# Altium schematic sheet Page2_.SchDoc
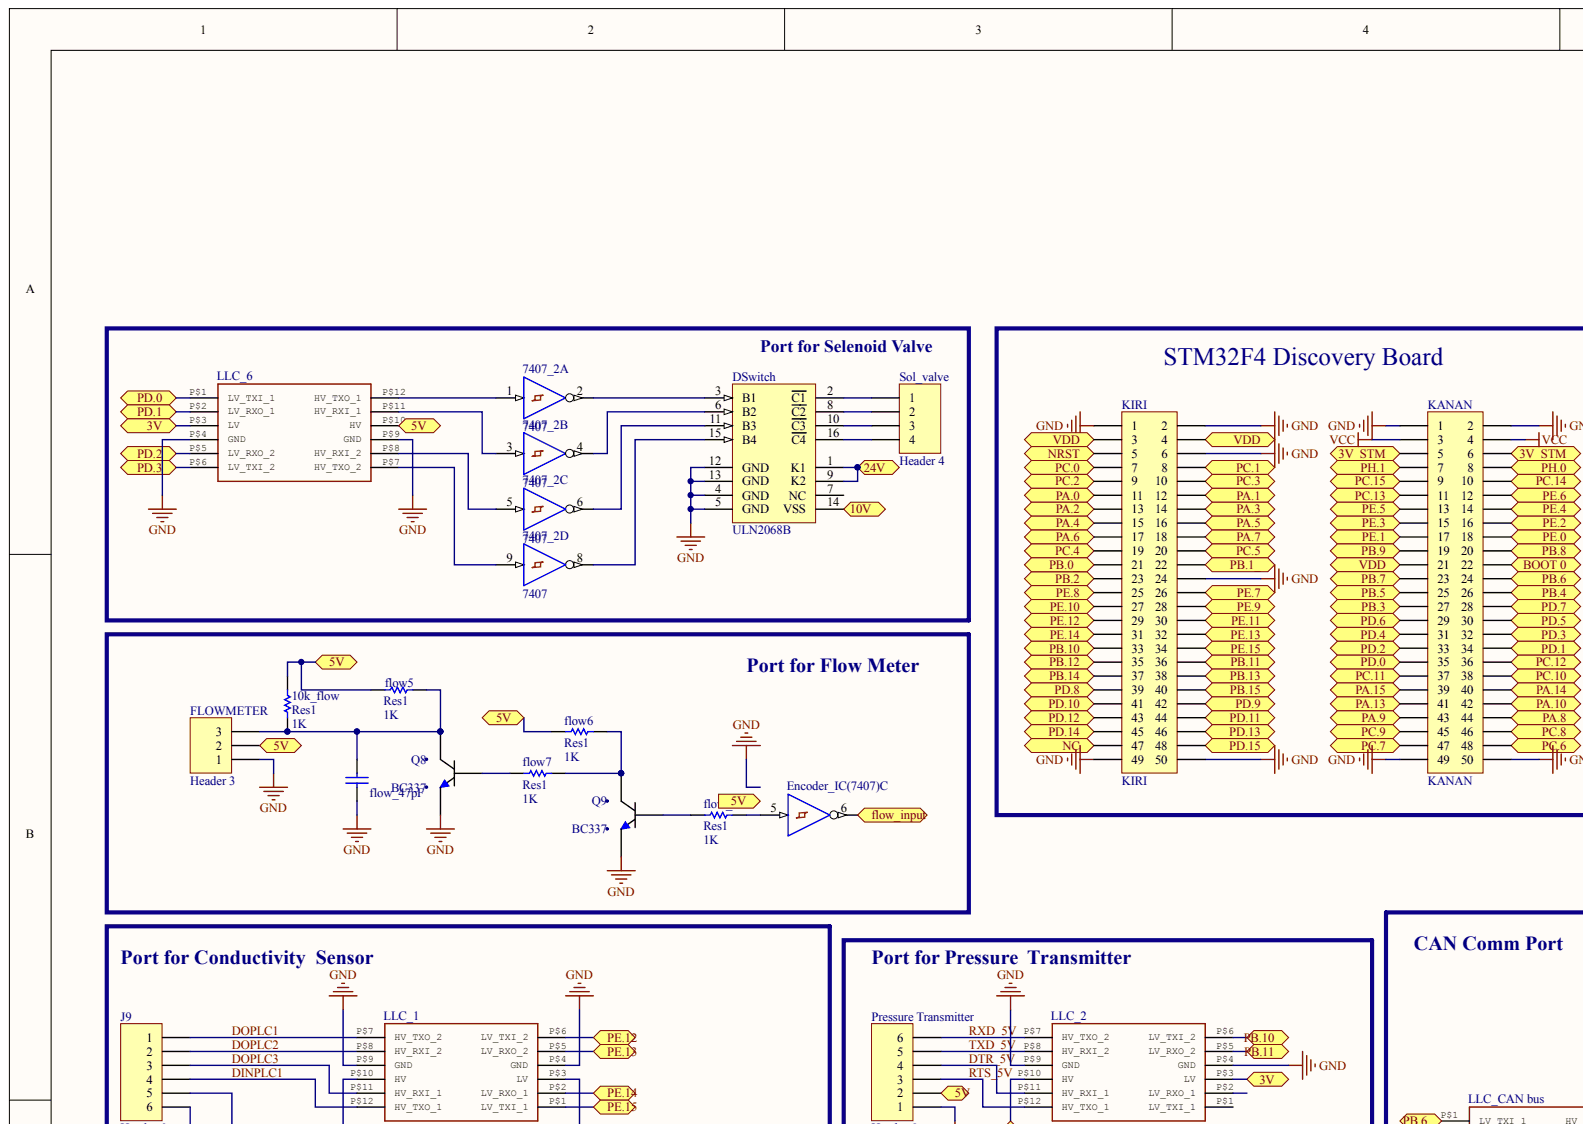
[diagram p0: Page2_.SchDoc, top left]
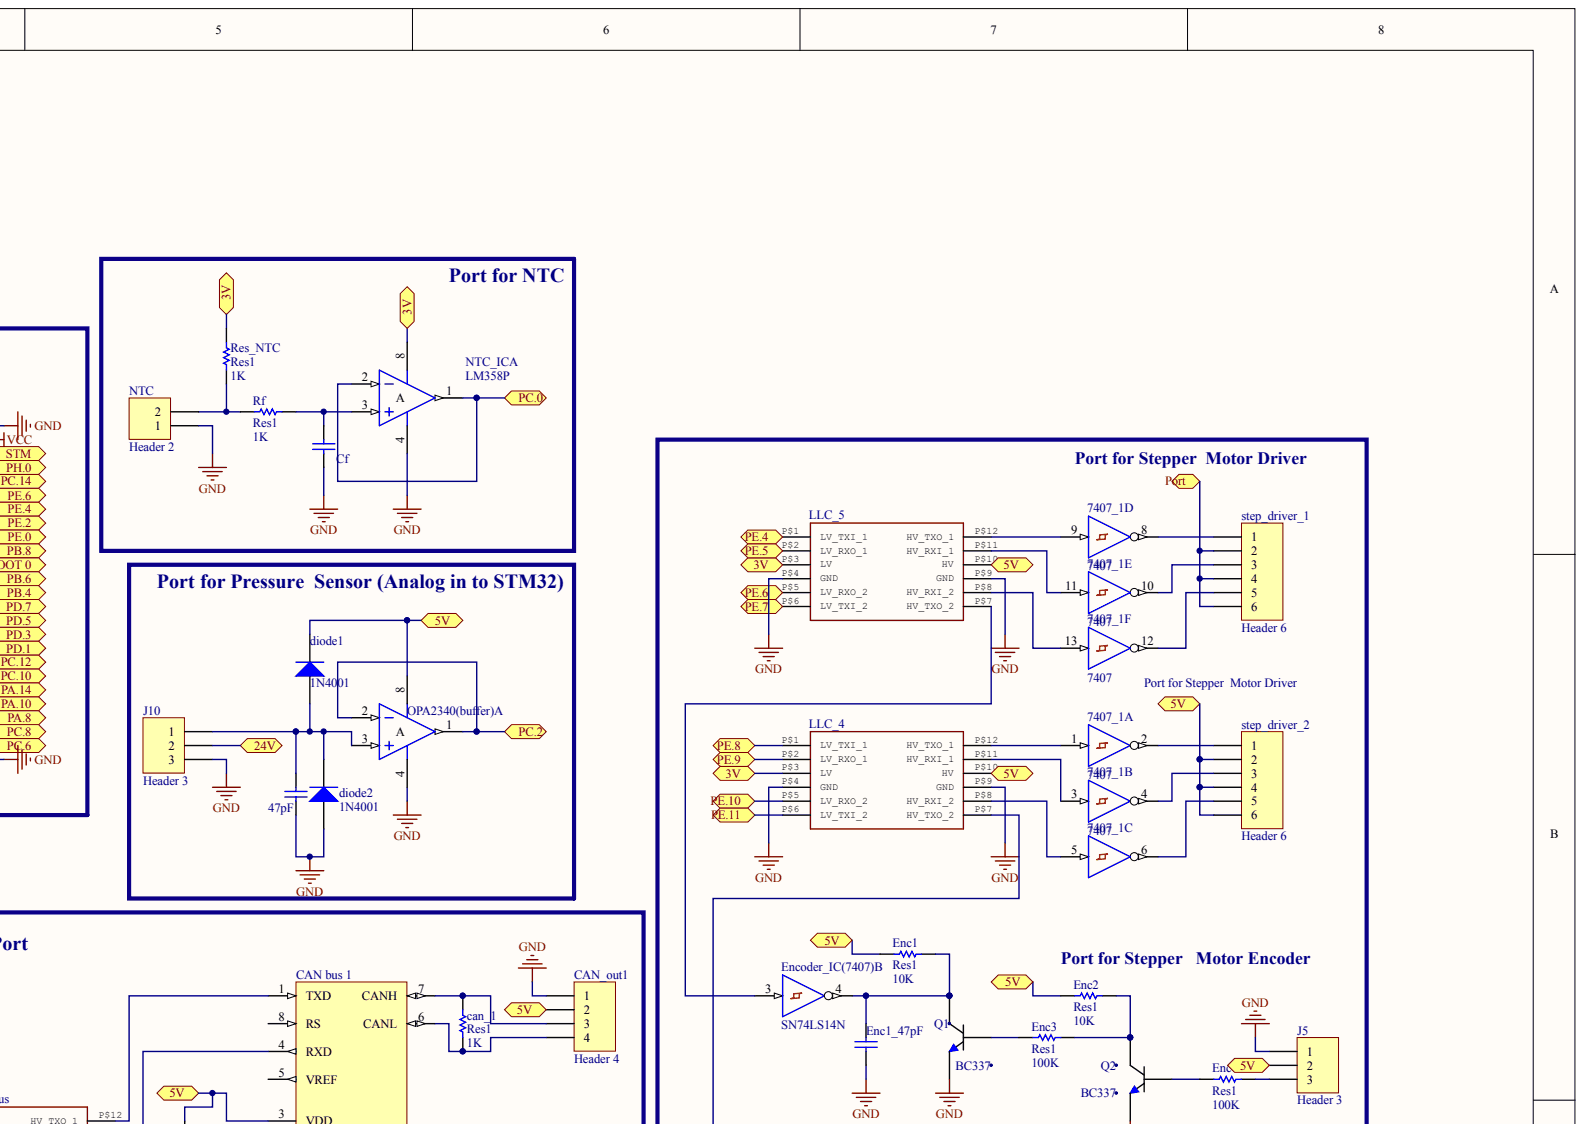
[diagram p1: Page2_.SchDoc, top right]
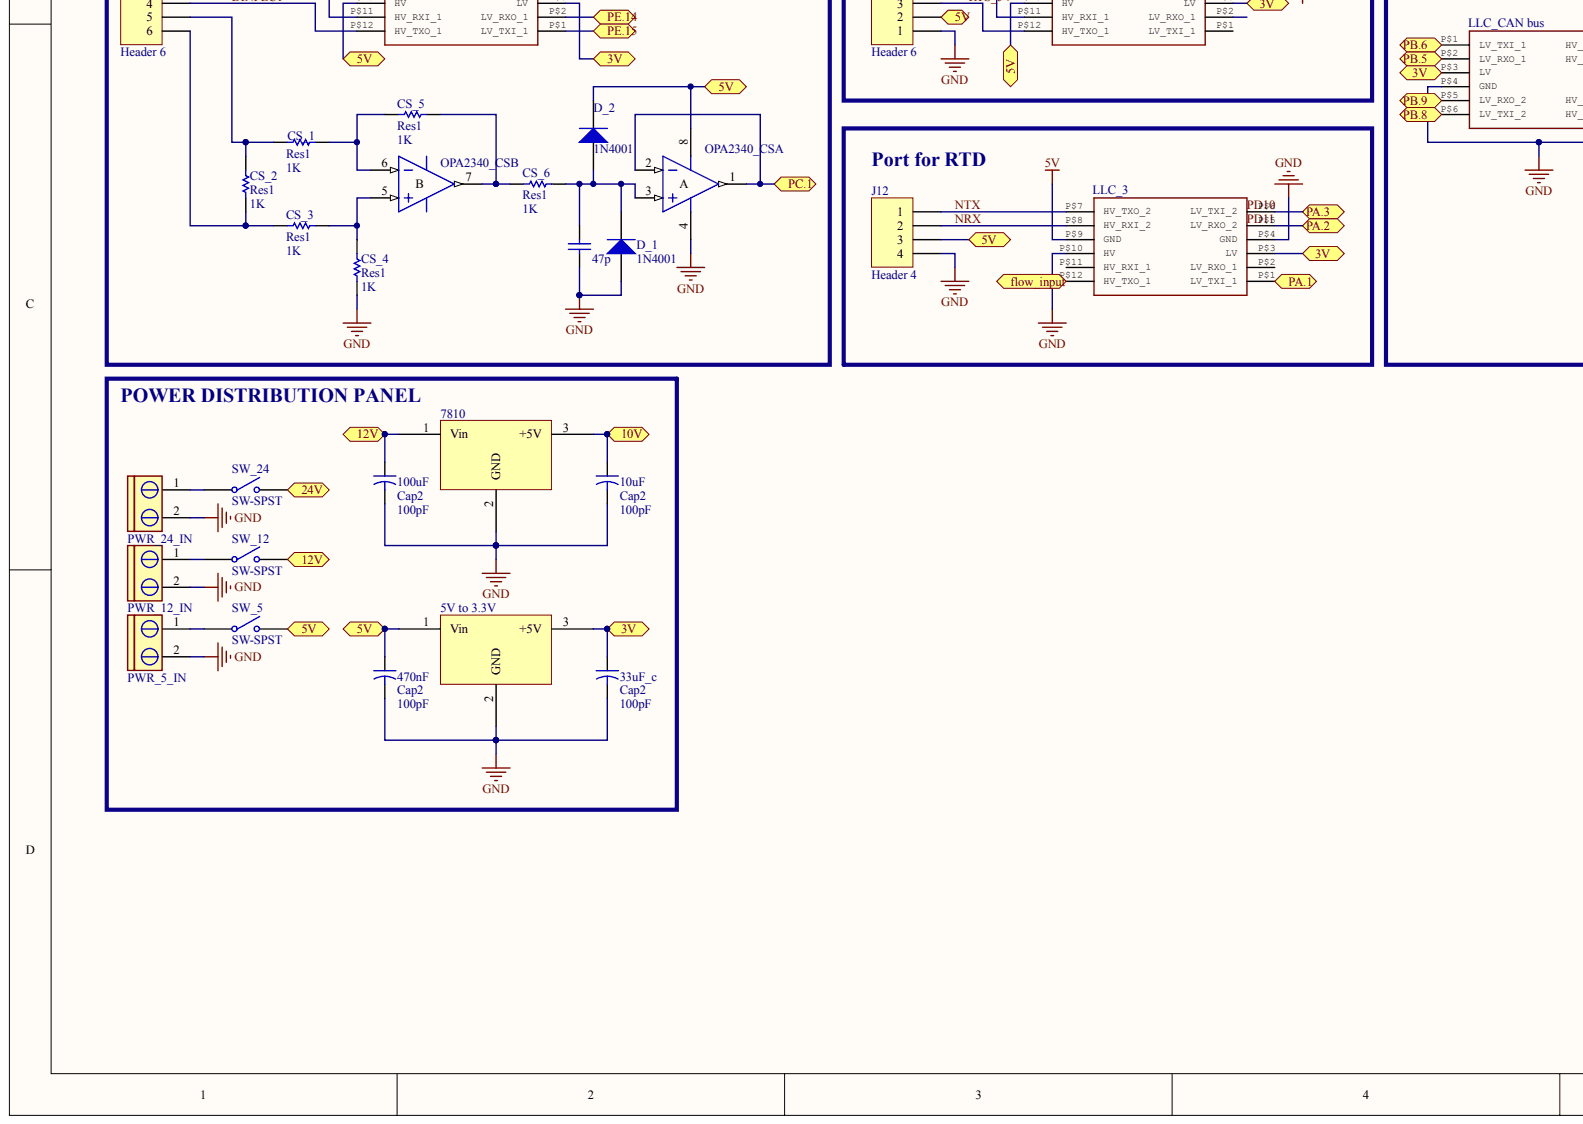
[diagram p2: Page2_.SchDoc, bottom left]
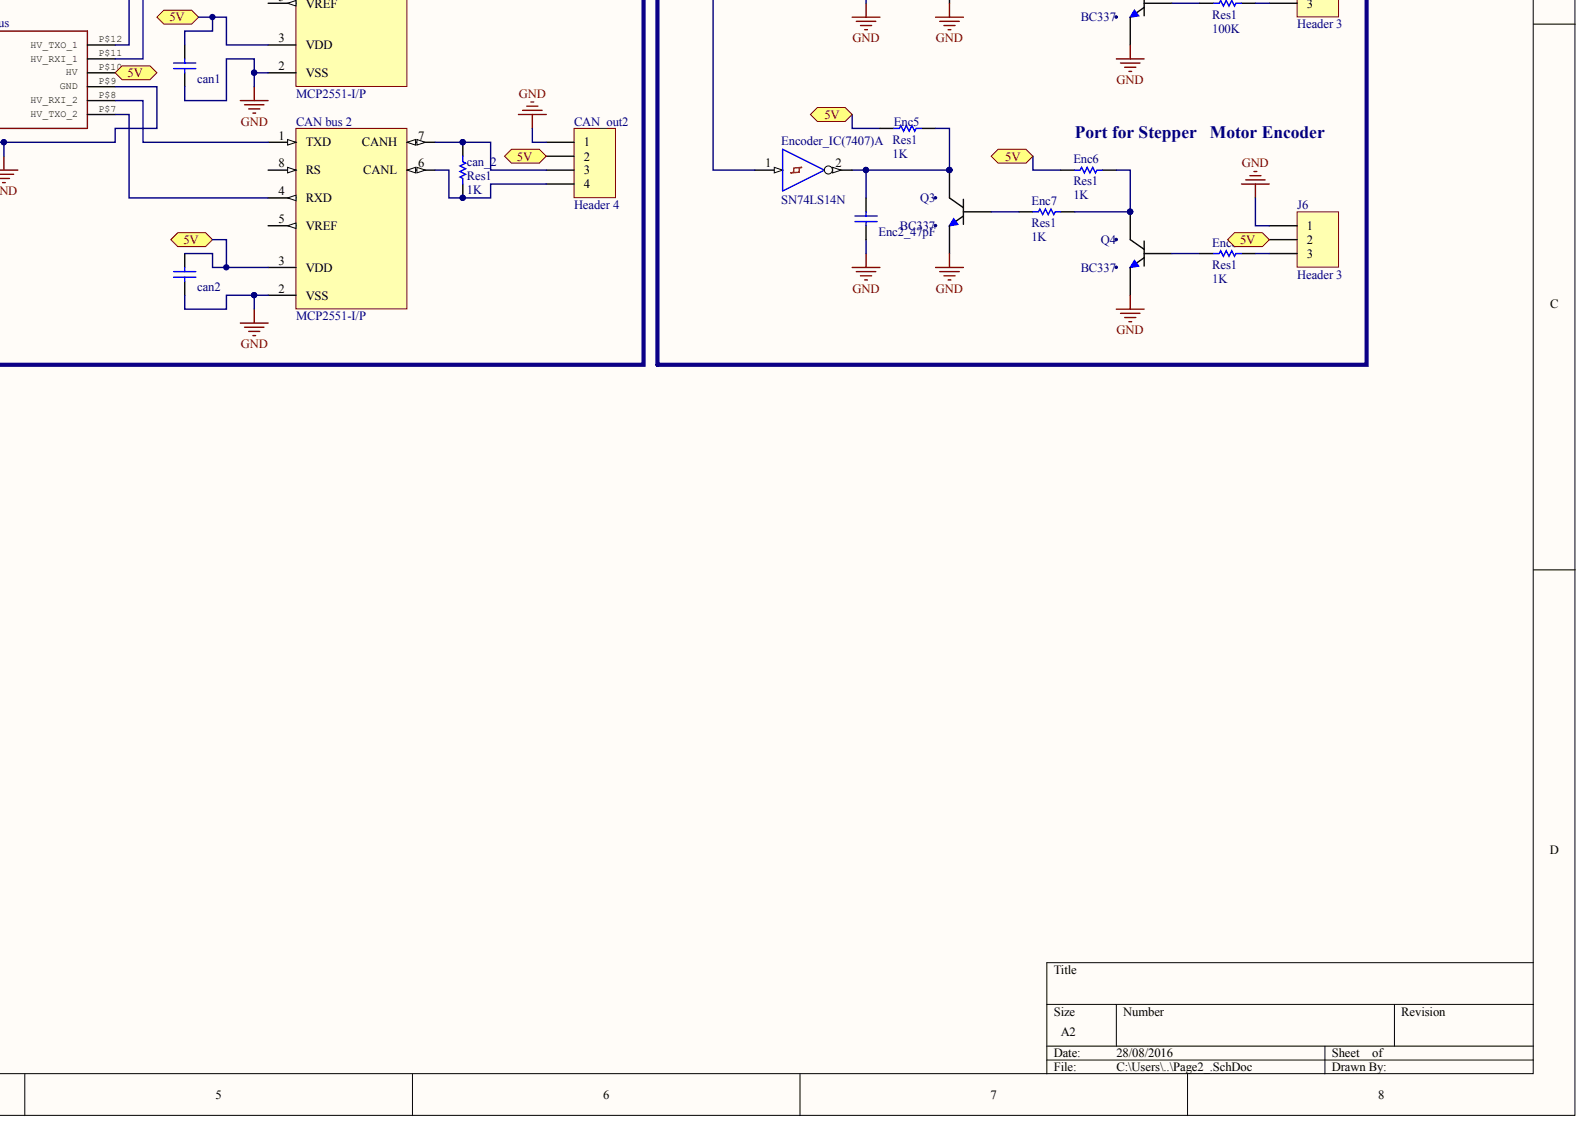
[diagram p3: Page2_.SchDoc, bottom right]

## Parts
5V_to_3.3V  7805  (value not drawn)
10k_flow  Res1  value 1K
10uF  Cap2  value 100pF
33uF_c  Cap2  value 100pF
47p  Cap  (value not drawn)
47pF  Cap  (value not drawn)
100uF  Cap2  value 100pF
470nF  Cap2  value 100pF
7407_1  7407  (parts A,B,C,D,E,F)
7407_2  7407  (parts A,B,C,D)
7810  7805  (value not drawn)
can1  Cap  (value not drawn)
can2  Cap  (value not drawn)
CAN_bus_1  MCP2551-I/P
CAN_bus_2  MCP2551-I/P
can_1  Res1  value 1K
can_2  Res1  value 1K
CAN_out1  Header 4
CAN_out2  Header 4
Cf  Cap  (value not drawn)
CS_1  Res1  value 1K
CS_2  Res1  value 1K
CS_3  Res1  value 1K
CS_4  Res1  value 1K
CS_5  Res1  value 1K
CS_6  Res1  value 1K
D_1  1N4001
D_2  1N4001
diode1  1N4001
diode2  1N4001
DSwitch  ULN2068B
Enc1  Res1  value 10K
Enc1_47pF  Cap  (value not drawn)
Enc2  Res1  value 10K
Enc2_47pF  Cap  (value not drawn)
Enc3  Res1  value 100K
Enc4  Res1  value 100K
Enc5  Res1  value 1K
Enc6  Res1  value 1K
Enc7  Res1  value 1K
Enc8  Res1  value 1K
Encoder_IC(7407)  SN74LS14N  (parts A,B,C)
flow5  Res1  value 1K
flow6  Res1  value 1K
flow7  Res1  value 1K
flow_1  Res1  value 1K
flow_47pF  Cap  (value not drawn)
FLOWMETER  Header 3
J5  Header 3
J6  Header 3
J9  Header 6
J10  Header 3
J12  Header 4
KANAN  KANAN
KIRI  KIRI
LLC_1  Logic Level Converter (3.3v - 5v) / https://www.sparkfun.com/products/8745  (value not drawn)
LLC_2  Logic Level Converter (3.3v - 5v) / https://www.sparkfun.com/products/8745  (value not drawn)
LLC_3  Logic Level Converter (3.3v - 5v) / https://www.sparkfun.com/products/8745  (value not drawn)
LLC_4  Logic Level Converter (3.3v - 5v) / https://www.sparkfun.com/products/8745  (value not drawn)
LLC_5  Logic Level Converter (3.3v - 5v) / https://www.sparkfun.com/products/8745  (value not drawn)
LLC_6  Logic Level Converter (3.3v - 5v) / https://www.sparkfun.com/products/8745  (value not drawn)
LLC_CAN_bus  Logic Level Converter (3.3v - 5v) / https://www.sparkfun.com/products/8745  (value not drawn)
NTC  Header 2
NTC_IC  LM358P  (part A)
OPA2340(buffer)  LM358P  (value not drawn)  (part A)
OPA2340_CS  LM358P  (value not drawn)  (parts A,B)
Pressure_Transmitter  Header 6
PWR_5_IN  CON2 pin blue  (value not drawn)
PWR_12_IN  CON2 pin blue  (value not drawn)
PWR_24_IN  CON2 pin blue  (value not drawn)
Q1  BC337
Q2  BC337
Q3  BC337
Q4  BC337
Q8  BC337
Q9  BC337
Res_NTC  Res1  value 1K
Rf  Res1  value 1K
Sol_valve  Header 4
step_driver_1  Header 6
step_driver_2  Header 6
SW_5  SW-SPST
SW_12  SW-SPST
SW_24  SW-SPST

## Ports
3V, 3V_STM, 5V, 10V, 12V, 24V, BOOT 0, FLOW_INPUT, NC, NRST, PA.0, PA.1, PA.2, PA.3, PA.4, PA.5, PA.6, PA.7, PA.8, PA.9, PA.10, PA.13, PA.14, PA.15, PB.0_, PB.1_, PB.2, PB.3, PB.4, PB.5, PB.6, PB.7, PB.8, PB.9, PB.10, PB.11, PB.12, PB.13, PB.14, PB.15, PC.0, PC.1, PC.2, PC.3, PC.4, PC.5, PC.6, PC.7, PC.8, PC.9, PC.10, PC.11, PC.12, PC.13, PC.14, PC.15, PD.0, PD.1, PD.2, PD.3, PD.4, PD.5, PD.6, PD.7, PD.8, PD.9, PD.10, PD.11, PD.12, PD.13, PD.14, PD.15, PE.0, PE.1, PE.2, PE.3, PE.4, PE.5, PE.6, PE.7, PE.8, PE.9, PE.10, PE.11, PE.12, PE.13, PE.14, PE.15, PH.0, PH.1, PORT, VDD

## Nets
5V: LLC_3.P$9(GND)
GND: 5V_to_3.3V.2 10uF.2 33uF_c.2 47p.1 47pF.1 100uF.2 470nF.2 7407_1.7 7407_2.7 7810.2 can1.1 can2.1 CAN_bus_1.2(VSS) CAN_bus_2.2(VSS) CAN_out1.1 CAN_out2.1 Cf.1 CS_4.1 D_1.1 diode2.1 DSwitch.4(GND) DSwitch.5(GND) DSwitch.12(GND) DSwitch.13(GND) Enc1_47pF.1 Enc2_47pF.1 Encoder_IC(7407).7 flow_47pF.1 FLOWMETER.1 J5.1 J6.1 J10.3 J12.4 KANAN.1 KANAN.2 KANAN.49 KANAN.50 KIRI.1 KIRI.2 KIRI.6 KIRI.24 KIRI.49 KIRI.50 LLC_1.P$4(GND) LLC_1.P$9(GND) LLC_2.P$4(GND) LLC_2.P$9(GND) LLC_3.P$4(GND) LLC_3.P$10(HV) LLC_4.P$4(GND) LLC_4.P$9(GND) LLC_5.P$4(GND) LLC_5.P$9(GND) LLC_6.P$4(GND) LLC_6.P$9(GND) LLC_CAN_bus.P$4(GND) LLC_CAN_bus.P$9(GND) NTC.1 NTC_IC.4 OPA2340(buffer).4 OPA2340_CS.4 Pressure_Transmitter.1 PWR_5_IN.2 PWR_12_IN.2 PWR_24_IN.2 Q1.3 Q2.3 Q3.3 Q4.3 Q8.3 Q9.3
VCC: KANAN.3 KANAN.4
VDD: 7407_1.14 7407_2.14 Encoder_IC(7407).14
3V: 5V_to_3.3V.3 33uF_c.1 LLC_1.P$3(LV) LLC_2.P$3(LV) LLC_3.P$3(LV) LLC_4.P$3(LV) LLC_5.P$3(LV) LLC_6.P$3(LV) LLC_CAN_bus.P$3(LV) NTC_IC.8 Res_NTC.2 ; port 3V
3V_STM: KANAN.5 KANAN.6 ; port 3V_STM
10V: 10uF.1 7810.3 DSwitch.14(VSS) ; port 10V
12V: 100uF.1 7810.1 SW_12.2 ; port 12V
24V: DSwitch.1(K1) DSwitch.9(K2) J10.2 SW_24.2 ; port 24V
BOOT 0: KANAN.22 ; port BOOT 0
DINPLC1: J9.4 LLC_1.P$12(HV_TXO_1)
DOPLC1: J9.1 LLC_1.P$7(HV_TXO_2)
DOPLC2: J9.2 LLC_1.P$8(HV_RXI_2)
DOPLC3: J9.3 LLC_1.P$11(HV_RXI_1)
DTR_5V: LLC_2.P$11(HV_RXI_1) Pressure_Transmitter.4
NC: KIRI.47 ; port NC
NRST: KIRI.5 ; port NRST
NRX: J12.2 LLC_3.P$8(HV_RXI_2)
NTX: J12.1 LLC_3.P$7(HV_TXO_2)
PA.0: KIRI.11 ; port PA.0
PA.1: KIRI.12 LLC_3.P$1(LV_TXI_1) ; port PA.1
PA.2: KIRI.13 LLC_3.P$5(LV_RXO_2) ; port PA.2
PA.3: KIRI.14 LLC_3.P$6(LV_TXI_2) ; port PA.3
PA.4: KIRI.15 ; port PA.4
PA.5: KIRI.16 ; port PA.5
PA.6: KIRI.17 ; port PA.6
PA.7: KIRI.18 ; port PA.7
PA.8: KANAN.44 ; port PA.8
PA.9: KANAN.43 ; port PA.9
PA.10: KANAN.42 ; port PA.10
PA.13: KANAN.41 ; port PA.13
PA.14: KANAN.40 ; port PA.14
PA.15: KANAN.39 ; port PA.15
PB.0_: KIRI.21 ; port PB.0_
PB.2: KIRI.23 ; port PB.2
PB.3: KANAN.27 ; port PB.3
PB.4: KANAN.26 ; port PB.4
PB.5: KANAN.25 LLC_CAN_bus.P$2(LV_RXO_1) ; port PB.5
PB.6: KANAN.24 LLC_CAN_bus.P$1(LV_TXI_1) ; port PB.6
PB.7: KANAN.23 ; port PB.7
PB.8: KANAN.20 LLC_CAN_bus.P$6(LV_TXI_2) ; port PB.8
PB.9: KANAN.19 LLC_CAN_bus.P$5(LV_RXO_2) ; port PB.9
PB.11: KIRI.36 LLC_2.P$5(LV_RXO_2) ; port PB.11
PB.12: KIRI.35 ; port PB.12
PB.13: KIRI.38 ; port PB.13
PB.14: KIRI.37 ; port PB.14
PB.15: KIRI.40 ; port PB.15
PC.0: KIRI.7 NTC_IC.1 NTC_IC.2 ; port PC.0
PC.1: KIRI.8 OPA2340_CS.1 OPA2340_CS.2 ; port PC.1
PC.2: KIRI.9 OPA2340(buffer).1 OPA2340(buffer).2 ; port PC.2
PC.3: KIRI.10 ; port PC.3
PC.4: KIRI.19 ; port PC.4
PC.5: KIRI.20 ; port PC.5
PC.6: KANAN.48 ; port PC.6
PC.7: KANAN.47 ; port PC.7
PC.8: KANAN.46 ; port PC.8
PC.9: KANAN.45 ; port PC.9
PC.10: KANAN.38 ; port PC.10
PC.11: KANAN.37 ; port PC.11
PC.12: KANAN.36 ; port PC.12
PC.13: KANAN.11 ; port PC.13
PC.14: KANAN.10 ; port PC.14
PC.15: KANAN.9 ; port PC.15
PD.0: KANAN.35 LLC_6.P$1(LV_TXI_1) ; port PD.0
PD.1: KANAN.34 LLC_6.P$2(LV_RXO_1) ; port PD.1
PD.2: KANAN.33 LLC_6.P$5(LV_RXO_2) ; port PD.2
PD.3: KANAN.32 LLC_6.P$6(LV_TXI_2) ; port PD.3
PD.4: KANAN.31 ; port PD.4
PD.5: KANAN.30 ; port PD.5
PD.6: KANAN.29 ; port PD.6
PD.7: KANAN.28 ; port PD.7
PD.8: KIRI.39 ; port PD.8
PD.9: KIRI.42 ; port PD.9
PD.10: KIRI.41 ; port PD.10
PD.11: KIRI.44 ; port PD.11
PD.12: KIRI.43 ; port PD.12
PD.13: KIRI.46 ; port PD.13
PD.14: KIRI.45 ; port PD.14
PD.15: KIRI.48 ; port PD.15
PE.0: KANAN.18 ; port PE.0
PE.1: KANAN.17 ; port PE.1
PE.2: KANAN.16 ; port PE.2
PE.3: KANAN.15 ; port PE.3
PE.4: KANAN.14 LLC_5.P$1(LV_TXI_1) ; port PE.4
PE.5: KANAN.13 LLC_5.P$2(LV_RXO_1) ; port PE.5
PE.6: KANAN.12 LLC_5.P$5(LV_RXO_2) ; port PE.6
PE.7: KIRI.26 LLC_5.P$6(LV_TXI_2) ; port PE.7
PE.8: KIRI.25 LLC_4.P$1(LV_TXI_1) ; port PE.8
PE.9: KIRI.28 LLC_4.P$2(LV_RXO_1) ; port PE.9
PE.10: KIRI.27 LLC_4.P$5(LV_RXO_2) ; port PE.10
PE.11: KIRI.30 LLC_4.P$6(LV_TXI_2) ; port PE.11
PE.12: KIRI.29 LLC_1.P$6(LV_TXI_2) ; port PE.12
PE.13: KIRI.32 LLC_1.P$5(LV_RXO_2) ; port PE.13
PE.14: KIRI.31 LLC_1.P$2(LV_RXO_1) ; port PE.14
PE.15: KIRI.34 LLC_1.P$1(LV_TXI_1) ; port PE.15
PH.0: KANAN.8 ; port PH.0
PH.1: KANAN.7 ; port PH.1
RTS_5V: LLC_2.P$12(HV_TXO_1) Pressure_Transmitter.3
RXD_5V: LLC_2.P$7(HV_TXO_2) Pressure_Transmitter.6
TXD_5V: LLC_2.P$8(HV_RXI_2) Pressure_Transmitter.5
Net5V to 3.3V_1: 5V_to_3.3V.1 10k_flow.2 470nF.1 can1.2 can2.2 CAN_bus_1.3(VDD) CAN_bus_2.3(VDD) CAN_out1.2 CAN_out2.2 D_2.2 diode1.2 Enc1.1 Enc2.1 Enc5.1 Enc6.1 flow5.1 flow6.1 FLOWMETER.2 J5.2 J6.2 J12.3 LLC_1.P$10(HV) LLC_2.P$10(HV) LLC_4.P$10(HV) LLC_5.P$10(HV) LLC_6.P$10(HV) LLC_CAN_bus.P$10(HV) OPA2340(buffer).8 OPA2340_CS.8 Pressure_Transmitter.2 step_driver_2.2 step_driver_2.4 step_driver_2.6 SW_5.2 ; port 5V
Net10k_flow_1: 10k_flow.1 flow5.2 flow_47pF.2 FLOWMETER.3 Q8.1
Net47p_2: 47p.2 CS_6.2 D_1.2 D_2.1 OPA2340_CS.3
Net47pF_2: 47pF.2 diode1.1 diode2.2 J10.1 OPA2340(buffer).3
Net7407_1_1: 7407_1.1 LLC_4.P$12(HV_TXO_1)
Net7407_1_2: 7407_1.2 step_driver_2.1
Net7407_1_3: 7407_1.3 LLC_4.P$11(HV_RXI_1)
Net7407_1_4: 7407_1.4 step_driver_2.3
Net7407_1_5: 7407_1.5 LLC_4.P$8(HV_RXI_2)
Net7407_1_6: 7407_1.6 step_driver_2.5
Net7407_1_8: 7407_1.8 step_driver_1.1
Net7407_1_9: 7407_1.9 LLC_5.P$12(HV_TXO_1)
Net7407_1_10: 7407_1.10 step_driver_1.3
Net7407_1_11: 7407_1.11 LLC_5.P$11(HV_RXI_1)
Net7407_1_12: 7407_1.12 step_driver_1.5
Net7407_1_13: 7407_1.13 LLC_5.P$8(HV_RXI_2)
Net7407_2_1: 7407_2.1 LLC_6.P$12(HV_TXO_1)
Net7407_2_2: 7407_2.2 DSwitch.3(B1)
Net7407_2_3: 7407_2.3 LLC_6.P$11(HV_RXI_1)
Net7407_2_4: 7407_2.4 DSwitch.6(B2)
Net7407_2_5: 7407_2.5 LLC_6.P$8(HV_RXI_2)
Net7407_2_6: 7407_2.6 DSwitch.11(B3)
Net7407_2_8: 7407_2.8 DSwitch.15(B4)
Net7407_2_9: 7407_2.9 LLC_6.P$7(HV_TXO_2)
NetCAN bus 1_1: CAN_bus_1.1(TXD) LLC_CAN_bus.P$12(HV_TXO_1)
NetCAN bus 1_4: CAN_bus_1.4(RXD) LLC_CAN_bus.P$11(HV_RXI_1)
NetCAN bus 2_1: CAN_bus_2.1(TXD) LLC_CAN_bus.P$8(HV_RXI_2)
NetCAN bus 2_4: CAN_bus_2.4(RXD) LLC_CAN_bus.P$7(HV_TXO_2)
Netcan_1_1: CAN_bus_1.6(CANL) can_1.1 CAN_out1.4
Netcan_1_2: CAN_bus_1.7(CANH) can_1.2 CAN_out1.3
Netcan_2_1: CAN_bus_2.6(CANL) can_2.1 CAN_out2.4
Netcan_2_2: CAN_bus_2.7(CANH) can_2.2 CAN_out2.3
NetCf_2: Cf.2 NTC_IC.3 Rf.2
NetCS_1_1: CS_1.1 CS_2.2 J9.5
NetCS_1_2: CS_1.2 CS_5.1 OPA2340_CS.6
NetCS_2_1: CS_2.1 CS_3.1 J9.6
NetCS_3_2: CS_3.2 CS_4.2 OPA2340_CS.5
NetCS_5_2: CS_5.2 CS_6.1 OPA2340_CS.7
NetDSwitch_2: DSwitch.2(C1) Sol_valve.1
NetDSwitch_8: DSwitch.8(C2) Sol_valve.2
NetDSwitch_10: DSwitch.10(C3) Sol_valve.3
NetDSwitch_16: DSwitch.16(C4) Sol_valve.4
NetEnc1_2: Enc1.2 Enc1_47pF.2 Encoder_IC(7407).4 Q1.1
NetEnc2_2: Enc2.2 Enc3.2 Q2.1
NetEnc2_47pF_2: Enc2_47pF.2 Enc5.2 Encoder_IC(7407).2 Q3.1
NetEnc3_1: Enc3.1 Q1.2
NetEnc4_1: Enc4.1 Q2.2
NetEnc4_2: Enc4.2 J5.3
NetEnc6_2: Enc6.2 Enc7.2 Q4.1
NetEnc7_1: Enc7.1 Q3.2
NetEnc8_1: Enc8.1 Q4.2
NetEnc8_2: Enc8.2 J6.3
NetEncoder_IC(7407)_1: Encoder_IC(7407).1 LLC_4.P$7(HV_TXO_2)
NetEncoder_IC(7407)_3: Encoder_IC(7407).3 LLC_5.P$7(HV_TXO_2)
NetEncoder_IC(7407)_5: Encoder_IC(7407).5 flow_1.2
NetEncoder_IC(7407)_6: Encoder_IC(7407).6 LLC_3.P$12(HV_TXO_1) ; port FLOW_INPUT
Netflow6_2: flow6.2 flow7.2 Q9.1
Netflow7_1: flow7.1 Q8.2
Netflow_1_1: flow_1.1 Q9.2
NetKANAN_21: KANAN.21 KIRI.3 KIRI.4 ; port VDD
NetKIRI_22: KIRI.22
NetKIRI_33: KIRI.33 LLC_2.P$6(LV_TXI_2)
NetNTC_2: NTC.2 Res_NTC.1 Rf.1
NetPWR_5_IN_1: PWR_5_IN.1 SW_5.1
NetPWR_12_IN_1: PWR_12_IN.1 SW_12.1
NetPWR_24_IN_1: PWR_24_IN.1 SW_24.1
Netstep_driver_1_2: step_driver_1.2 step_driver_1.4 step_driver_1.6 ; port PORT
PD10:
PD11:

Not connected: CAN_bus_1 5(VREF) 8(RS); CAN_bus_2 5(VREF) 8(RS); DSwitch 7(NC); LLC_2 P$1(LV_TXI_1) P$2(LV_RXO_1); LLC_3 P$2(LV_RXO_1) P$11(HV_RXI_1)

## Library data of the parts
47p 47pF can1 can2 Cf Enc1_47pF Enc2_47pF flow_47pF = (no symbol name): Value: 100nF
Enc2 = (no symbol name): Value: 10K; Published: 8-Jun-2000; LatestRevisionDate: 17-Jul-2002; LatestRevisionNote: Re-released for DXP Platform.; PackageReference: AXIAL-0.3; PackageDescription: Axial Device, Thru-Hole; 2 Leads; 0.3 in Pin Spacing; Publisher: Altium Limited
Enc6 flow6 = (no symbol name): Value: 1K; Published: 8-Jun-2000; LatestRevisionDate: 17-Jul-2002; LatestRevisionNote: Re-released for DXP Platform.; PackageReference: AXIAL-0.3; PackageDescription: Axial Device, Thru-Hole; 2 Leads; 0.3 in Pin Spacing; Publisher: Altium Limited
5V_to_3.3V 10k_flow 10uF 33uF_c 100uF 470nF 7407_1 7407_2 7810 can_1 can_2 CAN_bus_1 CAN_bus_2 CAN_out1 CAN_out2 CS_1-CS_6 D_1 D_2 diode1 diode2 DSwitch Enc1 Enc3-Enc5 Enc7 Enc8 Encoder_IC(7407) flow5 flow7 flow_1 FLOWMETER J5 J6 J9 J10 J12 KANAN KIRI LLC_1-LLC_6 LLC_CAN_bus NTC NTC_IC OPA2340(buffer) OPA2340_CS Pressure_Transmitter PWR_5_IN PWR_12_IN PWR_24_IN Q1-Q4 Q8 Q9 Res_NTC Rf Sol_valve step_driver_1 step_driver_2 SW_5 SW_12 SW_24 = (no symbol name)
  10k_flow can_1 can_2 CS_1-CS_6 Enc1 Enc3-Enc5 Enc7 Enc8 flow5 flow7 flow_1 Res_NTC Rf: Published: 8-Jun-2000; LatestRevisionDate: 17-Jul-2002; LatestRevisionNote: Re-released for DXP Platform.; PackageReference: AXIAL-0.3; PackageDescription: Axial Device, Thru-Hole; 2 Leads; 0.3 in Pin Spacing
  10uF 33uF_c 100uF 470nF: Published: 23-Sep-2002; PackageReference: CAPR5-4X5; PackageDescription: Capacitor; 2 Leads
  7407_1 7407_2 Encoder_IC(7407): Published: 15-Apr-2002; DatasheetVersion: 18-Oct-1996; PackageVersion: 1995; PackageReference: 646-06; PackageDescription: DIP; 14 Leads; Row Spacing 7.62 mm; Pitch 2.54 mm; ComponentLink1Description: Manufacturer Link; ComponentLink1URL: http://www.onsemi.com/; ComponentLink2Description: Datasheet; ComponentLink2URL: http://www.onsemi.com/pub/Collateral/SN74LS14-D.PDF
  CAN_bus_1 CAN_bus_2: Published: 29-Jan-2009; DatasheetVersion: revE, Jan-2007; PackageVersion: revBB, Aug-2009; PackageReference: PDIP300-P8; PackageDescription: 8-Lead Plastic Dual In-Line (P) - 300 mil Body [PDIP]; Supplier 1: Digi-Key; Supplier Part Number 1: MCP2551-I/P-ND; Supplier 2: Farnell; Supplier Part Number 2: 1292240; Code_JEDEC: MS-001; ComponentLink1Description: Datasheet; ComponentLink1URL: http://ww1.microchip.com/downloads/en/DeviceDoc/21667E.pdf; ComponentLink2Description: Packaging Specification; ComponentLink2URL: http://www.microchip.com/stellent/groups/techpub_sg/documents/packagingspec/en012702.pdf; ComponentLink3Description: Microchip.com Home; ComponentLink3URL: http://www.microchip.com/
  CAN_out1 CAN_out2 FLOWMETER J5 J6 J9 J10 J12 KANAN KIRI NTC Pressure_Transmitter Sol_valve step_driver_1 step_driver_2: LatestRevisionDate: 17-Jul-2002; LatestRevisionNote: Re-released for DXP Platform.
  D_1 D_2 diode1 diode2: Published: 8-Jun-2000; LatestRevisionDate: 17-Jul-2002; LatestRevisionNote: Re-released for DXP Platform.; DatasheetVersion: 1998; PackageVersion: Aug-1999; PackageReference: DO-41; PackageDescription: Diode, Axial; Body 5.2 x 2.7 mm (LxDia. max), Lead Dia. 0.86 mm (max); Code_JEDEC: DO-204-AL; ComponentLink1Description: Manufacturer Link; ComponentLink1URL: http://www.fairchildsemi.com/; ComponentLink2Description: Datasheet; ComponentLink2URL: http://www.fairchildsemi.com/ds/1N%2F1N4001.pdf
  DSwitch: Published: 17-Jul-2002; LatestRevisionDate: 06-Jun-2005; LatestRevisionNote: Stylized 3D Model Added.; DatasheetVersion: 1988; PackageReference: 648C-02; PackageDescription: DIP; 16 Leads; Row Spacing 7.62 mm; Pitch 2.54 mm
  NTC_IC OPA2340(buffer) OPA2340_CS: Published: 16-Jan-2001; LatestRevisionDate: 04-Mar-2005; LatestRevisionNote: Stylized 3D Model Added.; DatasheetVersion: Jul-1998; PackageVersion: Jun-1999; PackageReference: P008; PackageDescription: DIP; 8 Leads; Row Spacing 7.62 mm; Pitch 2.54 mm; Code_JEDEC: MO-001-AN; ComponentLink1Description: Manufacturer Link; ComponentLink1URL: http://www.ti.com/; ComponentLink2Description: Datasheet; ComponentLink2URL: http://www-s.ti.com/sc/ds/lm358.pdf
  SW_5 SW_12 SW_24: Published: 24-Mar-1999; LatestRevisionDate: 17-Jul-2002; LatestRevisionNote: Re-released for DXP Platform.; PackageReference: SPST-2; PackageDescription: Switch; 2 Leads
  10k_flow 10uF 33uF_c 100uF 470nF 7407_1 7407_2 can_1 can_2 CAN_bus_1 CAN_bus_2 CAN_out1 CAN_out2 CS_1-CS_6 D_1 D_2 diode1 diode2 DSwitch Enc1 Enc3-Enc5 Enc7 Enc8 Encoder_IC(7407) flow5 flow7 flow_1 FLOWMETER J5 J6 J9 J10 J12 KANAN KIRI NTC NTC_IC OPA2340(buffer) OPA2340_CS Pressure_Transmitter Res_NTC Rf Sol_valve step_driver_1 step_driver_2 SW_5 SW_12 SW_24: Publisher: Altium Limited
